# Altium schematic sheet H21R4_Frontend.SchDoc
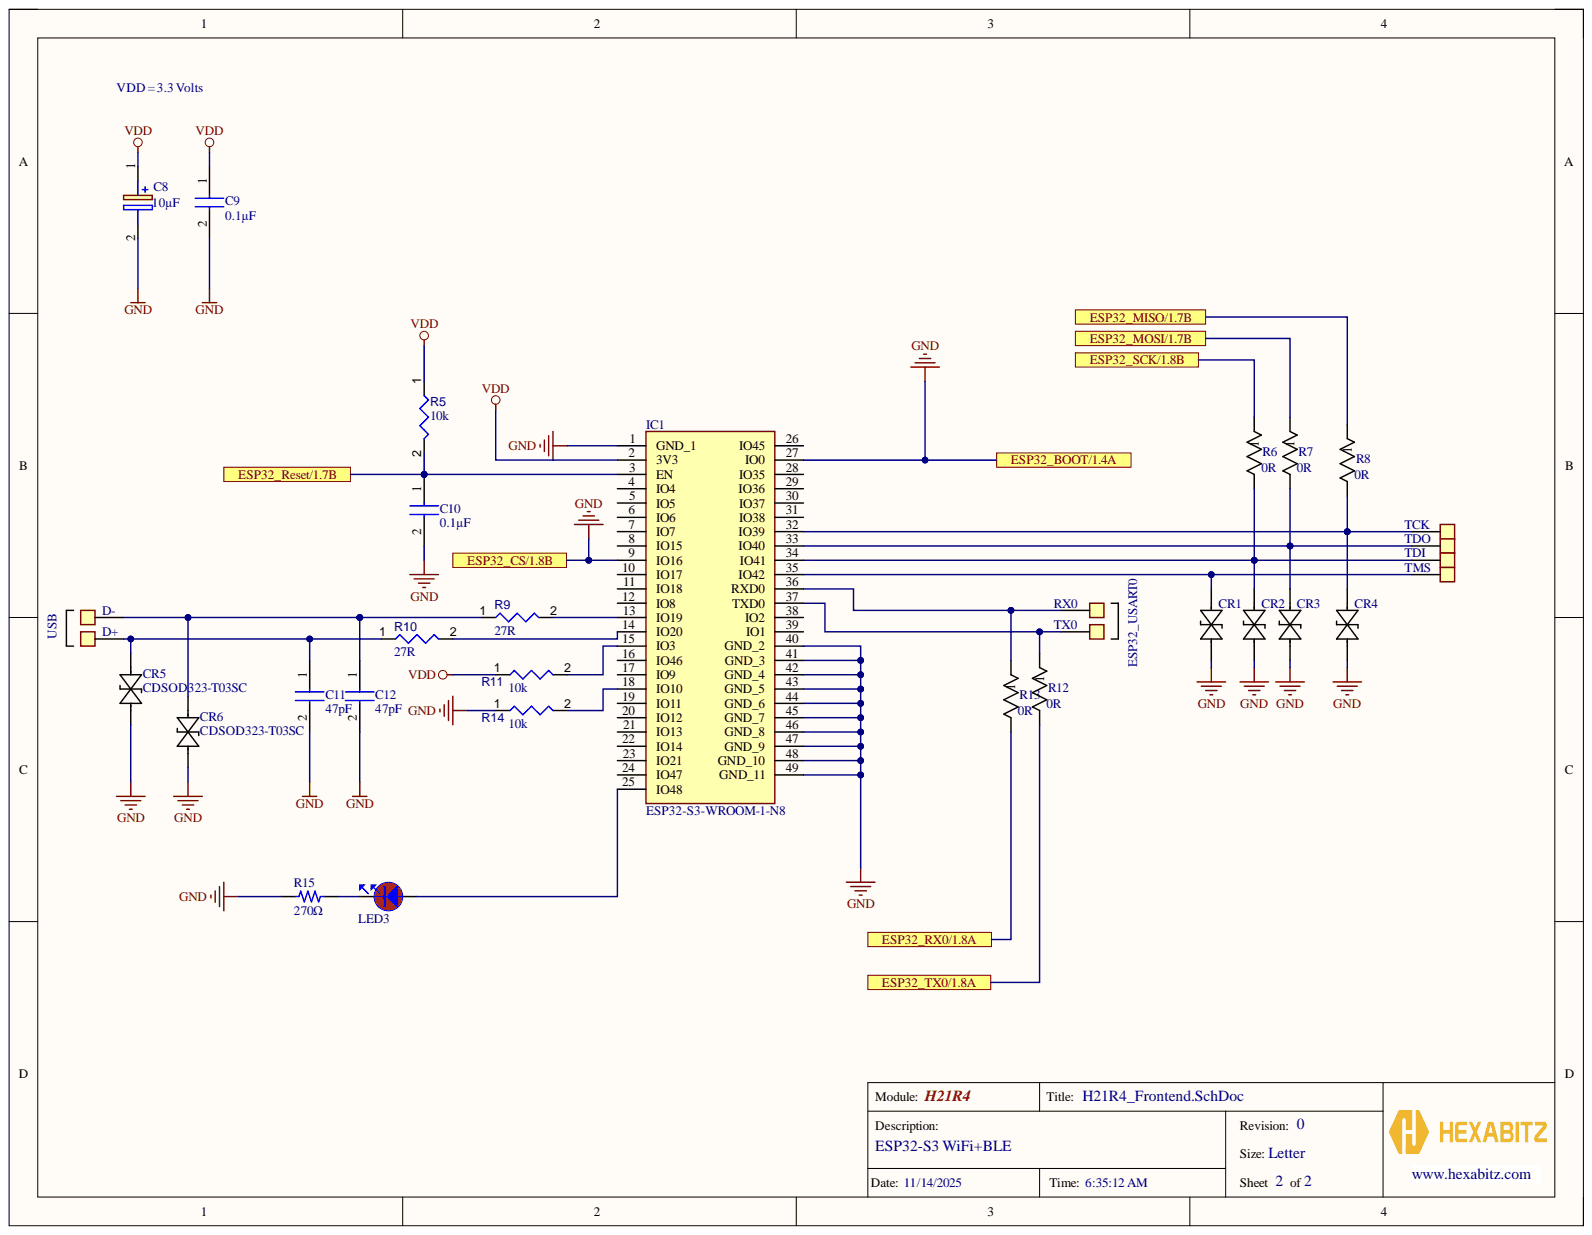
[diagram p0: H21R4_Frontend.SchDoc]

## Parts
C8  value 10µF  [CAPPM3528X210N]  (hidden comment: TPSB106K016R0500)
C9  value 0.1µF  [CAPC1608X87N]  (hidden comment: C0603C104K8RAC7867)
C10  value 0.1µF  [CAPC1608X87N]  (hidden comment: C0603C104K8RAC7867)
C11  value 47pF  [CAPC1608X90N]  (hidden comment: CL10C470JB8NNNC)
C12  value 47pF  [CAPC1608X90N]  (hidden comment: CL10C470JB8NNNC)
CR1  CDSOD323-T03SC  [SOD-323_BRN]
CR2  CDSOD323-T03SC  [SOD-323_BRN]
CR3  CDSOD323-T03SC  [SOD-323_BRN]
CR4  CDSOD323-T03SC  [SOD-323_BRN]
CR5  CDSOD323-T03SC  [SOD-323_BRN]
CR6  CDSOD323-T03SC  [SOD-323_BRN]
D+  HEXABIT_UART_PORT  [HEXABIT_POWER_PORT_U]  (value not drawn)  (no BOM)
D-  HEXABIT_UART_PORT  [HEXABIT_POWER_PORT_U]  (value not drawn)  (no BOM)
IC1  ESP32-S3-WROOM-1-N8  [ESP32S3WROOM1N8R2]
LED3  VLMS1300-GS08  [FP-6_541-5092_01-4-MFG]  (value not drawn)
R5  value 10k  [CAPC1508X06N]  (hidden comment: RMCF0603JT10K0)
R6  value 0R  [YAG_AC0402_YAG]  (hidden comment: AC0402JR-070RL)
R7  value 0R  [YAG_AC0402_YAG]  (hidden comment: AC0402JR-070RL)
R8  value 0R  [YAG_AC0402_YAG]  (hidden comment: AC0402JR-070RL)
R9  value 27R  [CAPC1608X06N]  (hidden comment: ERJ-3GEYJ270V)
R10  value 27R  [CAPC1608X06N]  (hidden comment: ERJ-3GEYJ270V)
R11  value 10k  [CAPC1508X06N]  (hidden comment: RMCF0603JT10K0)
R12  value 0R  [YAG_AC0402_YAG]  (hidden comment: AC0402JR-070RL)
R13  value 0R  [YAG_AC0402_YAG]  (hidden comment: AC0402JR-070RL)
R14  value 10k  [CAPC1508X06N]  (hidden comment: RMCF0603JT10K0)
R15  value 270Ω  [R0603]  (hidden comment: Manufacturer Part Number)
RX0  HEXABIT_UART_PORT  [HEXABIT_POWER_PORT_U]  (value not drawn)  (no BOM)
TCK  HEXABIT_UART_PORT  [HEXABIT_POWER_PORT_U]  (value not drawn)  (no BOM)
TDI  HEXABIT_UART_PORT  [HEXABIT_POWER_PORT_U]  (value not drawn)  (no BOM)
TDO  HEXABIT_UART_PORT  [HEXABIT_POWER_PORT_U]  (value not drawn)  (no BOM)
TMS  HEXABIT_UART_PORT  [HEXABIT_POWER_PORT_U]  (value not drawn)  (no BOM)
TX0  HEXABIT_UART_PORT  [HEXABIT_POWER_PORT_U]  (value not drawn)  (no BOM)

## Ports
ESP32_BOOT/1.4A, ESP32_CS/1.8B, ESP32_MISO/1.7B, ESP32_MOSI/1.7B, ESP32_Reset/1.7B, ESP32_RX0/1.8A, ESP32_SCK/1.8B, ESP32_TX0/1.8A

## Nets
VDD: C8.1 C9.1 IC1.2(3V3) R5.1 R11.1
ESP32_MISO/1.7B: R8.1 ; port ESP32_MISO/1.7B
ESP32_MOSI/1.7B: R7.1 ; port ESP32_MOSI/1.7B
ESP32_Reset/1.7B: C10.1 IC1.3(EN) R5.2 ; port ESP32_Reset/1.7B
ESP32_RX0/1.8A: R13.2 ; port ESP32_RX0/1.8A
ESP32_SCK/1.8B: R6.1 ; port ESP32_SCK/1.8B
ESP32_TX0/1.8A: R12.2 ; port ESP32_TX0/1.8A
GND: C8.2 C9.2 C10.2 C11.2 C12.2 CR1.2 CR2.2 CR3.2 CR4.2 CR5.2 CR6.2 IC1.1(GND_1) IC1.9(IO16) IC1.27(IO0) IC1.40(GND_2) IC1.41(GND_3) IC1.42(GND_4) IC1.43(GND_5) IC1.44(GND_6) IC1.45(GND_7) IC1.46(GND_8) IC1.47(GND_9) IC1.48(GND_10) IC1.49(GND_11) R14.1 R15.2 ; port ESP32_BOOT/1.4A, port ESP32_CS/1.8B
NetC11_1: C11.1 CR5.1 D+.Pwr_U R10.1
NetC12_1: C12.1 CR6.1 D-.Pwr_U R9.1
NetCR1_1: CR1.1 IC1.35(IO42)
NetCR2_1: CR2.1 IC1.34(IO41) R6.2
NetCR3_1: CR3.1 IC1.33(IO40) R7.2
NetCR4_1: CR4.1 IC1.32(IO39) R8.2
NetIC1_13: IC1.13(IO19) R9.2
NetIC1_14: IC1.14(IO20) R10.2
NetIC1_15: IC1.15(IO3) R11.2
NetIC1_18: IC1.18(IO10) R14.2
NetIC1_25: IC1.25(IO48) LED3.2
NetIC1_36: IC1.36(RXD0) R13.1
NetIC1_37: IC1.37(TXD0) R12.1
NetLED3_1: LED3.1 R15.1

Not connected: IC1 4(IO4) 5(IO5) 6(IO6) 7(IO7) 8(IO15) 10(IO17) 11(IO18) 12(IO8) 16(IO46) 17(IO9) 19(IO11) 20(IO12) 21(IO13) 22(IO14) 23(IO21) 24(IO47) 26(IO45) 28(IO35) 29(IO36) 30(IO37) 31(IO38) 38(IO2) 39(IO1); RX0 Pwr_U; TCK Pwr_U; TDI Pwr_U; TDO Pwr_U; TMS Pwr_U; TX0 Pwr_U

## Library data of the parts
C8 = TPSB106K016R0500: Capacitor Polarised; Manufacturer_Name: Kyocera AVX; Arrow Part Number: TPSB106K016R0500; Arrow Price/Stock: https://www.arrow.com/en/products/tpsb106k016r0500/avx?utm_currency=USD&region=nac; Component Kind: Standard; Datasheet Link: https://componentsearchengine.com/Datasheets/2/TPSB106K016R0500.pdf; Height: 2.1mm; Manufacturer_Part_Number: TPSB106K016R0500; Mouser Part Number: 581-TPSB106K016R0500; Mouser Price/Stock: https://www.mouser.co.uk/ProductDetail/KYOCERA-AVX/TPSB106K016R0500?qs=2Zc1E7vIw%252B6V4Ir4qQ11Ew%3D%3D
C9 C10 = C0603C104K8RAC7867: Capacitor; Manufacturer_Name: KEMET; Arrow Part Number: C0603C104K8RAC7867; Arrow Price/Stock: https://www.arrow.com/en/products/c0603c104k8rac7867/kemet-corporation?region=nac; Component Kind: Standard; Datasheet Link: https://labcenter.componentsearchengine.com/Datasheets/1/C0603C104K8RAC7867.pdf; Height: 0.87mm; Manufacturer_Part_Number: C0603C104K8RAC7867; Mouser Part Number: N/A; Mouser Price/Stock: https://www.mouser.co.uk/ProductDetail/KEMET/C0603C104K8RAC7867?qs=DLNzJaHuXGcLxwK2qWBfDA%3D%3D
C11 C12 = CL10C470JB8NNNC: Capacitor; Manufacturer_Name: SAMSUNG; Arrow Part Number: CL10C470JB8NNNC; Arrow Price/Stock: https://www.arrow.com/en/products/cl10c470jb8nnnc/samsung-electro-mechanics?region=nac; Component Kind: Standard; Datasheet Link: http://uk.rs-online.com/web/p/products/7665095; Height: 0.9mm; Manufacturer_Part_Number: CL10C470JB8NNNC; Mouser Part Number: 187-CL10C470JB8NNNC; Mouser Price/Stock: https://www.mouser.co.uk/ProductDetail/Samsung-Electro-Mechanics/CL10C470JB8NNNC?qs=349EhDEZ59pmluRkjzIjBw%3D%3D
CR1-CR6 = CMP-009-000000-1: Description; Manufacturer_Name: Bourns Electronics; Component Kind: Standard; Design Item ID: CDSOD323-T03SC; Manufacturer_Part_Number: CDSOD323-T03SC; Source: CDSOD323-T03SC.SchLib; Copyright: Copyright (C) 2025 Ultra Librarian. All rights reserved.; Library Name: Wesley Walker
D+ D- RX0 TCK TDI TDO TMS TX0 = HEXABIT_POWER_PORT_U: Library Name: hexabitz_connectors.Library.IntLib
IC1 = ESP32-S3-WROOM-1-N8: SMD MODULE, ESP32-S3, 8 MB SPI F; Manufacturer_Name: Espressif Systems; Component Kind: Standard; Datasheet Link: https://www.espressif.com/sites/default/files/documentation/esp32-s3-wroom-1_wroom-1u_datasheet_en.pdf; Height: 3.25mm; Manufacturer_Part_Number: ESP32-S3-WROOM-1-N8; Mouser Part Number: 356-ESP32-S3WROOM1N8; Mouser Price/Stock: https://www.mouser.co.uk/ProductDetail/Espressif-Systems/ESP32-S3-WROOM-1-N8?qs=Wj%2FVkw3K%252BMC1mq0KGPvoSQ%3D%3D
LED3 = VLMS1300-GS08: LED Uni-Color Super Red 639nm 2-Pin Chip 0603(1608Metric) T/R; Manufacturer: Vishay Semiconductors; Dominant Wavelength: 631nm; Forward Current: 20mA; Forward Voltage: 2V; Lens Style: Rectangular,Clear; Library: Hexabitz; Luminous Intensity: 54mcd; Number of LEDs: 1; Power Rating: 75mW; Viewing Angle: 130°; Case Code (Imperial): 0603; Case Code (Metric): 1608; Case/Package: 0603; Category: LEDs; Color: Red; Component Kind: Standard; ComponentLink1Description: https://datasheet.ciiva.com/26322/vlmo1300-26322153.pdf?src-supplier=Element14; ComponentLink1URL: https://datasheet.ciiva.com/26322/vlmo1300-26322153.pdf?src-supplier=Element14; ComponentLink2Description: https://datasheet.ciiva.com/8028/vlmo1300-8028047.pdf?src-supplier=Element14; ComponentLink2URL: https://datasheet.ciiva.com/8028/vlmo1300-8028047.pdf?src-supplier=Element14; ComponentLink3Description: https://datasheet.ciiva.com/9333/vlmo1300-9333743.pdf?src-supplier=Avnet; ComponentLink3URL: https://datasheet.ciiva.com/9333/vlmo1300-9333743.pdf?src-supplier=Avnet; ComponentLink4Description: https://datasheet.ciiva.com/16510/vlmo1300-16510985.pdf?src-supplier=Future+Electronics; ComponentLink4URL: https://datasheet.ciiva.com/16510/vlmo1300-16510985.pdf?src-supplier=Future+Electronics; ComponentLink5Description: https://datasheet.ciiva.com/2114/vlmo1300-2114930.pdf?src-supplier=Digikey; ComponentLink5URL: https://datasheet.ciiva.com/2114/vlmo1300-2114930.pdf?src-supplier=Digikey; ComponentLink6Description: https://datasheet.ciiva.com/2114/vlmo1300-2114931.pdf?src-supplier=Digikey; ComponentLink6URL: https://datasheet.ciiva.com/2114/vlmo1300-2114931.pdf?src-supplier=Digikey; ComponentLink7Description: https://datasheet.ciiva.com/2114/vlmo1300-2114929.pdf?src-supplier=Digikey; ComponentLink7URL: https://datasheet.ciiva.com/2114/vlmo1300-2114929.pdf?src-supplier=Digikey; Height: 0.8mm; Illumination Color: Red; Lead Free: Lead Free; Length: 1.6mm; Library Name: Hexabitz Altium Library.IntLib; Manufacturer Part Number: VLMS1300-GS08; Max Operating Temperature: 85°C; Min Operating Temperature: -35°C; Mounting Technology: Surface Mount; Package Quantity: 1; Packaging: Tape and Reel; Pricing: 1=0.21, 10=0.143, 100=0.0998, 500=0.07982, 1000=0.07322 (USD); Radiation Hardening: No; Reach SVHC Compliant: Unknown; RoHS: Yes; RoHS Compliant: Yes; Series: CHipLED; Stock: 56745; Supplier: Digikey; Supplier 1: Digikey; Supplier Part Number: VLMS1300-GS08DKR-ND; Supplier Part Number 1: VLMS1300-GS08DKR-ND; Test Current: 20mA; Wavelength: 631nm; Width: 0.8mm
R5 R11 R14 = CMP-009-00177-1: Res Thick Film 0603 10K Ohm 5% 1/10W ±200ppm/°C Molded SMD Paper T/R; Voltage Rating: 75V; Case/Package: 0603; Component Kind: Standard; Max Operating Temperature: 155°C; Min Operating Temperature: -55°C; Mounting Technology: Surface Mount; Tolerance: 5%; Library Name: Wesley Walker
R6-R8 R12 R13 = CMP-009-00179-1: No Description Available; Manufacturer_Name: Yageo; Component Kind: Standard; Design Item ID: AC0402JR-070RL; Manufacturer_Part_Number: AC0402JR-070RL; Source: AC0402JR-070RL.SchLib; Copyright: Copyright (C) 2025 Ultra Librarian. All rights reserved.; Library Name: Wesley Walker
R9 R10 = CMP-009-00178-1: Res Thick Film 0603 27 Ohm 5% 1/10W +/-200ppm/ßC Molded SMD Punched Carrier T/R; Voltage Rating: 75V; Case/Package: 0603; Component Kind: Standard; Max Operating Temperature: 155°C; Min Operating Temperature: -55°C; Mounting Technology: Surface Mount; Tolerance: 5%; Library Name: Wesley Walker
R15 = generic Resistor: Res Thick Film 0603 270 Ohm 5% 0.1W(1/10W) ±200ppm/C Pad SMD Automotive T/R; Manufacturer: Panasonic; Manufacturer Part Number: ERJ-3GEYJ271V; Library: Hexabitz; Max Power Dissipation: 100mW; Temperature Coefficient: 200ppm/°C; Voltage Rating: 75V; Case Code (Imperial): 0603; Case/Package: 0603; Category: Chip SMD Resistors; Component Kind: Standard; ComponentLink1Description: https://datasheet.octopart.com:443/ERJ-3GEYJ271V-Panasonic-datasheet-13266872.pdf?src-supplier=RS+(Formerly+Allied+Electronics); ComponentLink1URL: https://datasheet.octopart.com:443/ERJ-3GEYJ271V-Panasonic-datasheet-13266872.pdf?src-supplier=RS+(Formerly+Allied+Electronics); ComponentLink2Description: https://datasheet.octopart.com:443/ERJ-3GEYJ271V-Panasonic-datasheet-5314550.pdf?src-supplier=Future+Electronics; ComponentLink2URL: https://datasheet.octopart.com:443/ERJ-3GEYJ271V-Panasonic-datasheet-5314550.pdf?src-supplier=Future+Electronics; ComponentLink3Description: https://datasheet.octopart.com:443/ERJ-3GEYJ271V-Panasonic-datasheet-61114972.pdf?src-supplier=Panasonic+SCT; ComponentLink3URL: https://datasheet.octopart.com:443/ERJ-3GEYJ271V-Panasonic-datasheet-61114972.pdf?src-supplier=Panasonic+SCT; ComponentLink4Description: https://datasheet.octopart.com:443/ERJ-3GEYJ271V-Panasonic-datasheet-147443676.pdf?src-supplier=Master+Electronics; ComponentLink4URL: https://datasheet.octopart.com:443/ERJ-3GEYJ271V-Panasonic-datasheet-147443676.pdf?src-supplier=Master+Electronics; ComponentLink5Description: https://datasheet.octopart.com:443/ERJ-3GEYJ271V-Panasonic-datasheet-10554283.pdf?src-supplier=element14+APAC; ComponentLink5URL: https://datasheet.octopart.com:443/ERJ-3GEYJ271V-Panasonic-datasheet-10554283.pdf?src-supplier=element14+APAC; ComponentLink6Description: https://datasheet.octopart.com:443/ERJ3GEYJ271V-Panasonic-datasheet-165581512.pdf?src-supplier=TME; ComponentLink6URL: https://datasheet.octopart.com:443/ERJ3GEYJ271V-Panasonic-datasheet-165581512.pdf?src-supplier=TME; ComponentLink7Description: https://datasheet.octopart.com:443/ERJ-3GEYJ271V-Panasonic-datasheet-164353788.pdf?src-supplier=Newark; ComponentLink7URL: https://datasheet.octopart.com:443/ERJ-3GEYJ271V-Panasonic-datasheet-164353788.pdf?src-supplier=Newark; ComponentLink8Description: https://datasheet.octopart.com:443/ERJ3GEYJ271V-Panasonic-datasheet-136608608.pdf?src-supplier=Farnell; ComponentLink8URL: https://datasheet.octopart.com:443/ERJ3GEYJ271V-Panasonic-datasheet-136608608.pdf?src-supplier=Farnell; ComponentLink9Description: https://datasheet.octopart.com:443/ERJ-3GEYJ271V-Panasonic---ECG-datasheet-15994758.pdf?src-supplier=Farnell; ComponentLink9URL: https://datasheet.octopart.com:443/ERJ-3GEYJ271V-Panasonic---ECG-datasheet-15994758.pdf?src-supplier=Farnell; ComponentLink10Description: https://datasheet.octopart.com:443/ERJ-3GEYJ271V-Panasonic-datasheet-5313456.pdf?src-supplier=Farnell; ComponentLink10URL: https://datasheet.octopart.com:443/ERJ-3GEYJ271V-Panasonic-datasheet-5313456.pdf?src-supplier=Farnell; ComponentLink11Description: https://datasheet.octopart.com/ERJ-3GEYJ271V-Panasonic-datasheet-78375410.pdf; ComponentLink11URL: https://datasheet.octopart.com/ERJ-3GEYJ271V-Panasonic-datasheet-78375410.pdf; ComponentLink12Description: https://datasheet.octopart.com/Thick-Film-Chip-Resistors-Panasonic-datasheet-family-105.pdf; ComponentLink12URL: https://datasheet.octopart.com/Thick-Film-Chip-Resistors-Panasonic-datasheet-family-105.pdf; ComponentLink13Description: https://datasheet.octopart.com:443/ERJ-3GEYJ271V-Panasonic-datasheet-7538305.pdf?src-supplier=Jameco; ComponentLink13URL: https://datasheet.octopart.com:443/ERJ-3GEYJ271V-Panasonic-datasheet-7538305.pdf?src-supplier=Jameco; ComponentLink14Description: https://datasheet.octopart.com:443/ERJ-3GEYJ271V-Panasonic-datasheet-118655.pdf?src-supplier=DigiKey; ComponentLink14URL: https://datasheet.octopart.com:443/ERJ-3GEYJ271V-Panasonic-datasheet-118655.pdf?src-supplier=DigiKey; ComponentLink15Description: https://datasheet.octopart.com:443/ERJ3GEYJ271V-Panasonic-datasheet-27908757.pdf?src-supplier=Electro+Sonic; ComponentLink15URL: https://datasheet.octopart.com:443/ERJ3GEYJ271V-Panasonic-datasheet-27908757.pdf?src-supplier=Electro+Sonic; ComponentLink16Description: https://datasheet.octopart.com:443/ERJ-3GEYJ271V-Panasonic-datasheet-37173568.pdf?src-supplier=Electro+Sonic; ComponentLink16URL: https://datasheet.octopart.com:443/ERJ-3GEYJ271V-Panasonic-datasheet-37173568.pdf?src-supplier=Electro+Sonic; Composition: Thick Film; Contact Plating: Tin; Depth: 800µm; Features: Automotive AEC-Q200; Height: 450µm; Lead Free: Lead Free; Length: 1.6mm; Library Name: Hexabitz Altium Library.IntLib; Lifecycle Status: Production; Max Operating Temperature: 155°C; Military Standard: Not; Min Operating Temperature: -55°C; Mounting Technology: Surface Mount; Number of Terminals: 2; Number of Terminations: 2; Packaging: Cut Tape; Power Dissipation: 100mW; Power Rating: 100mW; Pricing: 5000=0.00355, 10000=0.00348, 20000=0.00342, 30000=0.00335, 40000=0.00329 (USD); Radiation Hardening: No; REACH SVHC: Yes; RoHS: Compliant; Schedule B: 8533210045, 8533210045|8533210045|8533210045|8533210045|8533210045|8533210045|8533210045|8533210045|8533210045|8533210045|8533210045|8533210045|8533210045|8533210045|8533210045|8533210045|8533210045|8533210045|8533210045|8533210045|8533210045|8533210045|8533210045|85; Stock: 45000; Supplier: Avnet; Supplier Part Number: ERJ-3GEYJ271V; Termination: SMD/SMT; Tolerance: 5%; Voltage Rating (DC): 75V; Width: 800µm; Working Voltage: 75V
